# Altium schematic sheet USB-2.0.SchDoc
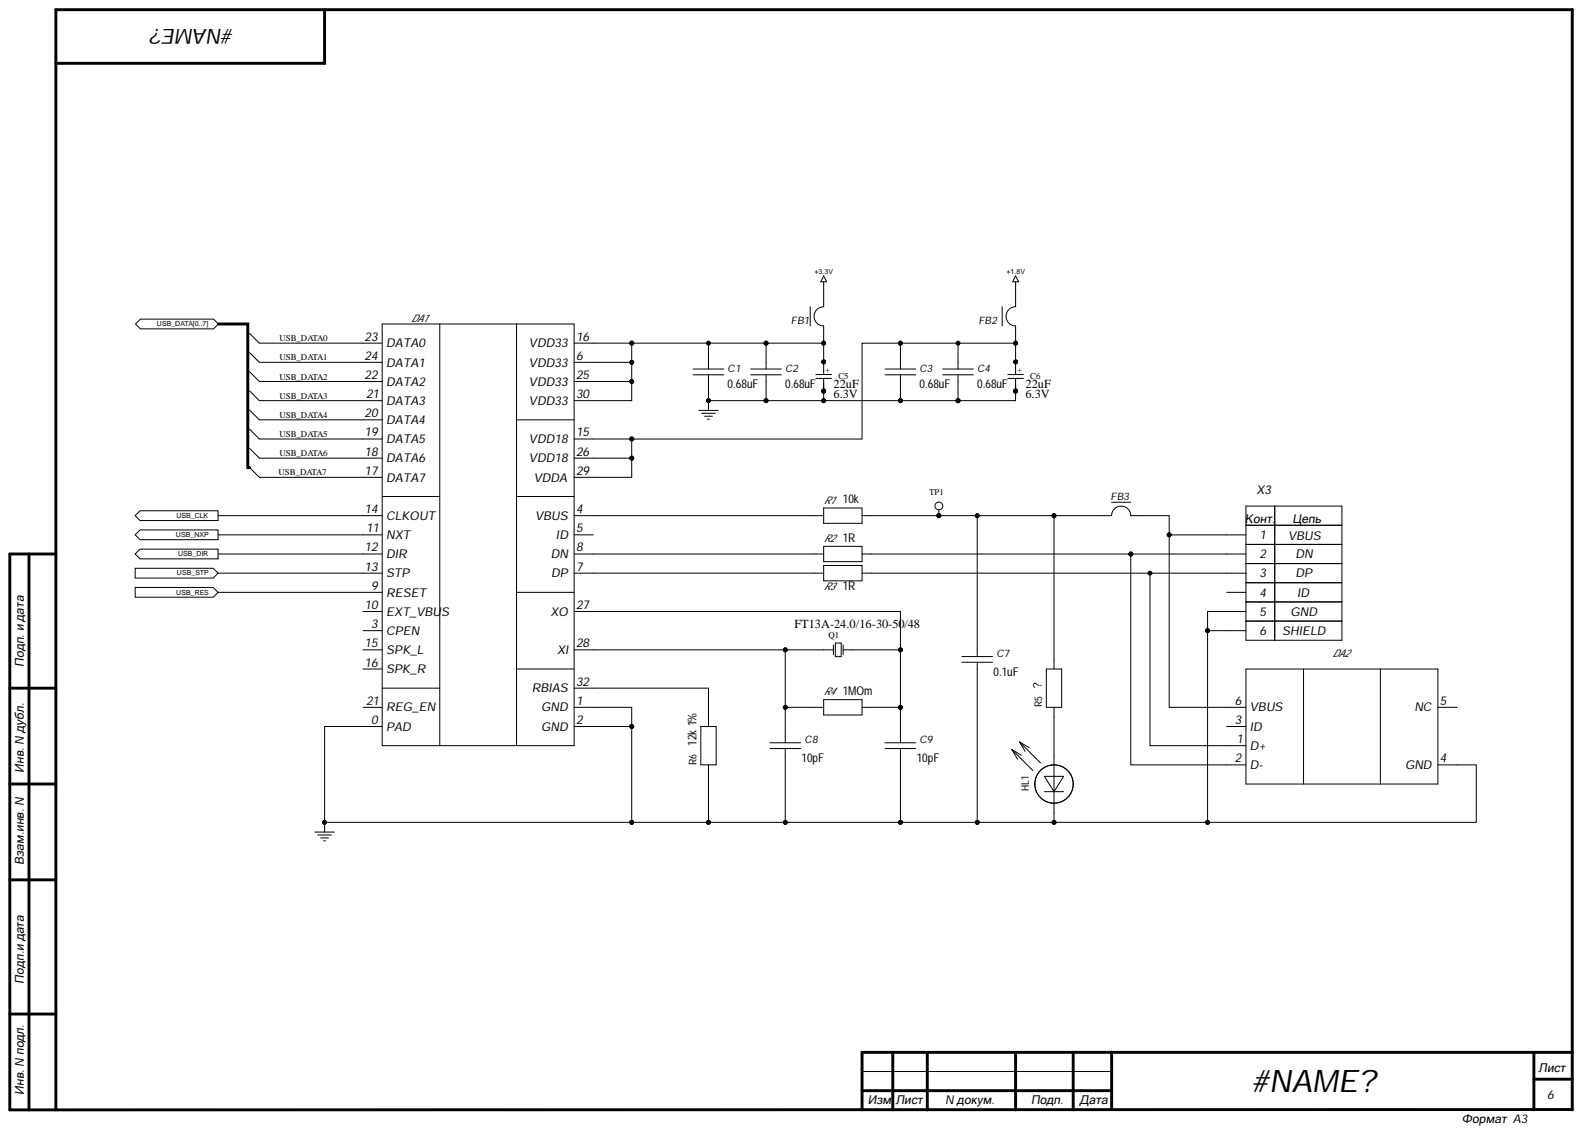
[diagram p0: USB-2.0.SchDoc]

## Parts
C1  value 0.68uF  (hidden comment: Cap)
C2  value 0.68uF  (hidden comment: Cap)
C3  value 0.68uF  (hidden comment: Cap)
C4  value 0.68uF  (hidden comment: Cap)
C5  value 22uF  Voltage: 6.3V  [(B)3528]  (hidden comment: сap)
C6  value 22uF  Voltage: 6.3V  [(B)3528]  (hidden comment: сap)
C7  value 0.1uF  (hidden comment: Cap)
C8  value 10pF  (hidden comment: Cap)
C9  value 10pF  (hidden comment: Cap)
DA1  USB3300-EZK  (value not drawn)
DA2  (no value)
FB1  (no value)
FB2  (no value)
FB3  (no value)
HL1  (no value)
Q1  value FT13A-24.0/16-30-50/48  [OSC-7M]  (hidden comment: XTAL)
R1  value 10k  (hidden comment: Res2)
R2  value 1R  (hidden comment: Res2)
R3  value 1R  (hidden comment: Res2)
R4  value 1MOm  (hidden comment: Res2)
R5  Res2  (value not drawn)
R6  value 12k  Precision: 1%  (hidden comment: Res2)
TP1  (no value)  [TP_0MM5_1MM0]  (no BOM)
X3  Circuit 1: VBUS  Circuit 2: DN  Circuit 3: DP  Circuit 4: ID  Circuit 5: GND  Circuit 6: SHIELD

## Ports
USB_CLK, USB_DATA0, USB_DATA1, USB_DATA2, USB_DATA3, USB_DATA4, USB_DATA5, USB_DATA6, USB_DATA7, USB_DATA[0..7], USB_DIR, USB_NXP, USB_RES, USB_STP

## Nets
+1.8V: FB2.2
+3.3V: FB1.2
GND: C1.1 C2.1 C3.1 C4.1 C5.2 C6.2 C7.2 C8.2 C9.2 DA1.0(PAD) DA1.1(GND) DA1.2(GND) DA2.4(GND) HL1.2 R6.1 X3.5 X3.6
USB_CLK: DA1.14(CLKOUT) ; port USB_CLK
USB_DATA0: DA1.23(DATA0)
USB_DATA1: DA1.24(DATA1)
USB_DATA2: DA1.22(DATA2)
USB_DATA3: DA1.21
USB_DATA4: DA1.20(DATA4)
USB_DATA5: DA1.19(DATA5)
USB_DATA6: DA1.18(DATA6)
USB_DATA7: DA1.17(DATA7)
USB_DIR: DA1.12(DIR) ; port USB_DIR
USB_NXP: DA1.11(NXT) ; port USB_NXP
USB_RES: DA1.9(RESET) ; port USB_RES
USB_STP: DA1.13(STP) ; port USB_STP
NetC1_2: C1.2 C2.2 C5.1 DA1.6(VDD33) DA1.16 DA1.25(VDD33) DA1.30(VDD33) FB1.1
NetC3_2: C3.2 C4.2 C6.1 DA1.15 DA1.26(VDD18) DA1.29(VDDA) FB2.1
NetC7_1: C7.1 FB3.1 R1.1 R5.1 TP1.1
NetC8_1: C8.1 DA1.28(XI) Q1.1 R4.2
NetC9_1: C9.1 DA1.27(XO) Q1.3 R4.1
NetDA1_4: DA1.4(VBUS) R1.2
NetDA1_7: DA1.7(DP) R3.1
NetDA1_8: DA1.8(DN) R2.1
NetDA1_32: DA1.32(RBIAS) R6.2
NetDA2_1: DA2.1(D+) R3.2 X3.3
NetDA2_2: DA2.2(D-) R2.2 X3.2
NetDA2_6: DA2.6(VBUS) FB3.2 X3.1
NetHL1_1: HL1.1 R5.2
N00001_0: ; port USB_DATA[0..7]
N00001_1: ; port USB_DATA[0..7]
N00001_2: ; port USB_DATA[0..7]
N00001_3: ; port USB_DATA[0..7]
N00001_4: ; port USB_DATA[0..7]
N00001_5: ; port USB_DATA[0..7]
N00001_6: ; port USB_DATA[0..7]
N00001_7: ; port USB_DATA[0..7]

Not connected: DA1 3(CPEN) 5(ID) 10(EXT_VBUS); DA2 3(ID) 5(NC); X3 4

## Library data of the parts
C1 = Cap: Конденсатор; Value: 0.68uF; Component Kind: Standard; PackageReference: 0603; Signal Integrity: Cap; Library Name: Terra.SCHLIB
C2-C4 C7-C9 = Cap: Конденсатор; Component Kind: Standard; PackageReference: 0603; Signal Integrity: Cap; Library Name: Terra.SCHLIB
C5 C6 = PCap: Конденсатор керамический; Info: X5R 10%  GRM32ER61A226; Component Kind: Standard; Library Name: common.SCHLIB; PackageReference: "B" 3528; Signal Integrity: Cap; Simulation: CAP
DA1 = USB3300: USB-2.0 driver; Component Kind: Standard; PackageReference: QFN32; Value: USB3300-EZK
DA2 = TPD4S012DRYR: 4-Channel ESD Solution for USB-HS/USB OTG/USB; Component Kind: Standard; Value: TPD4S012DRYR
FB1-FB3 = Inductor: Component Kind: Standard
HL1 = DIODE-LED-GOST: Component Kind: Standard
Q1 = XTAL: Кварцевый резонатор; Component Kind: Standard; Library Name: common.SCHLIB; PackageReference: KX7; PCB3D: SW3dPS-hc49; Simulation: XTAL
R1-R6 = Res2: Резистор; Component Kind: Standard; PackageReference: 0603; Signal Integrity: Res; Library Name: Terra.SCHLIB
  R5: Value: ?
TP1 = Test_Point: Тестовая точка на плате; MarkPCB: +5V
X3 = CONNECTOR_6: Component Kind: Standard; System: connector
